# Altium schematic sheet remote_lab_test_beam_v2.00.SchDoc
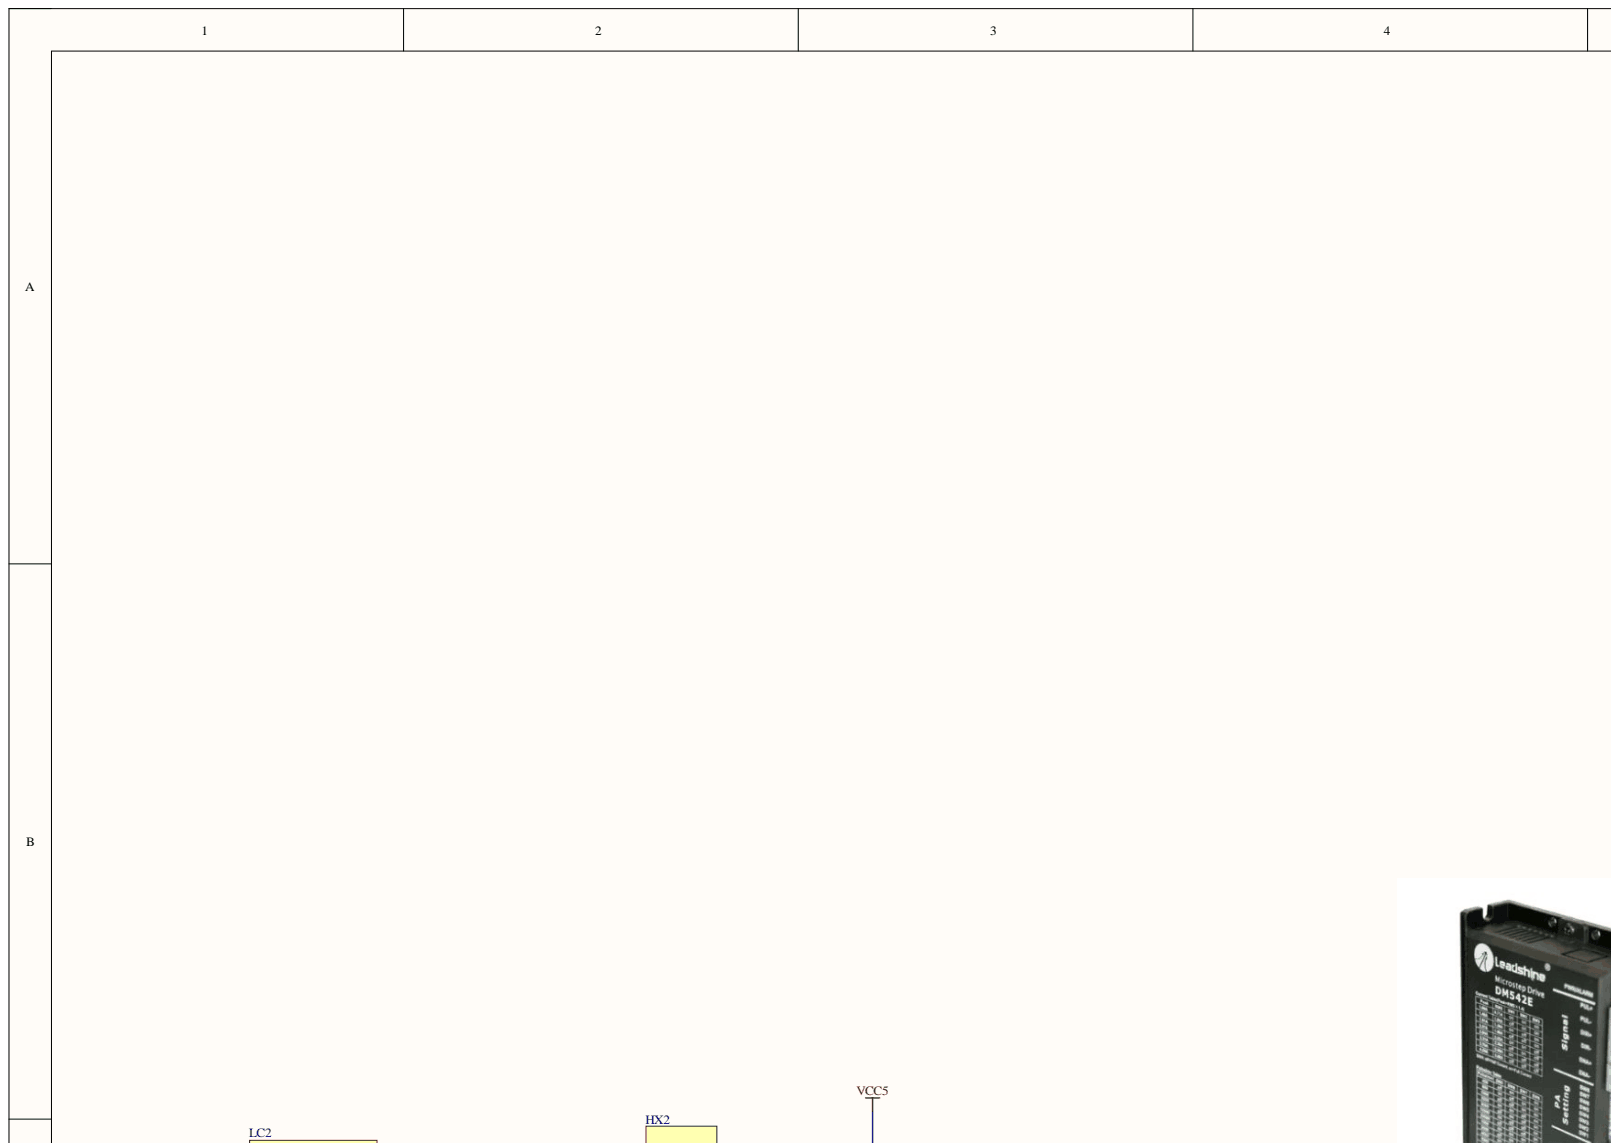
[diagram p0: remote_lab_test_beam_v2.00.SchDoc, top left]
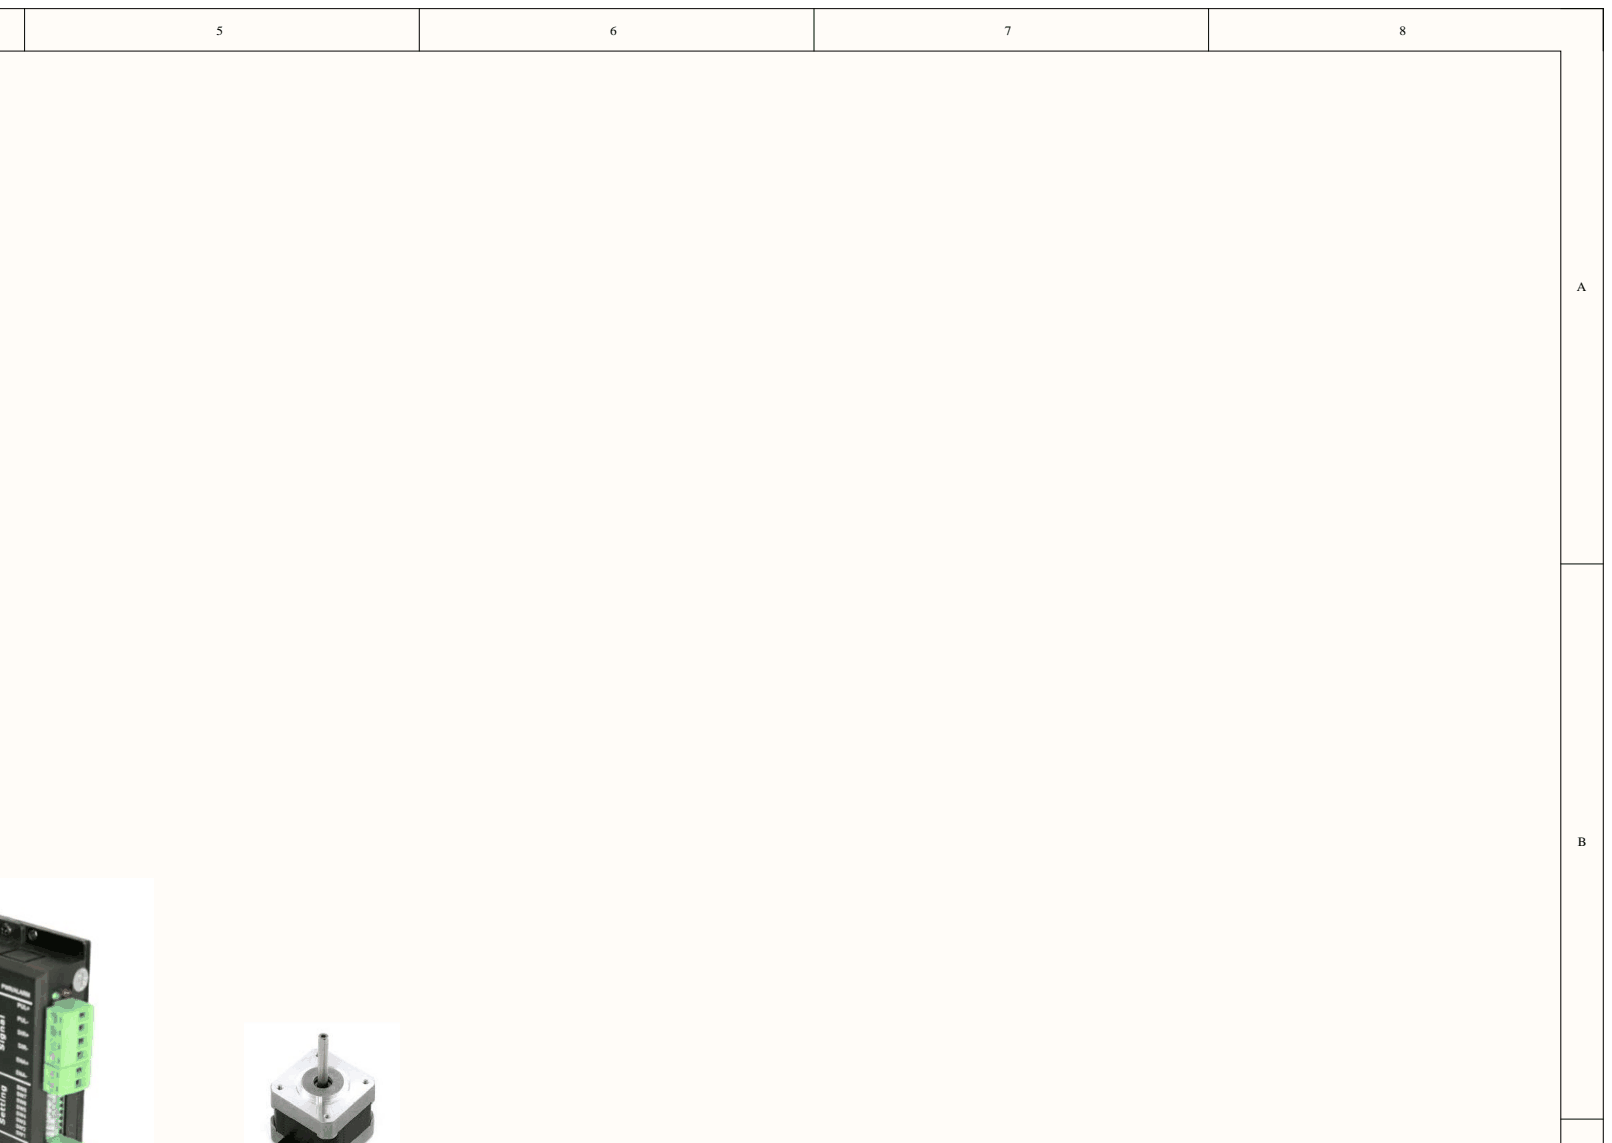
[diagram p1: remote_lab_test_beam_v2.00.SchDoc, top right]
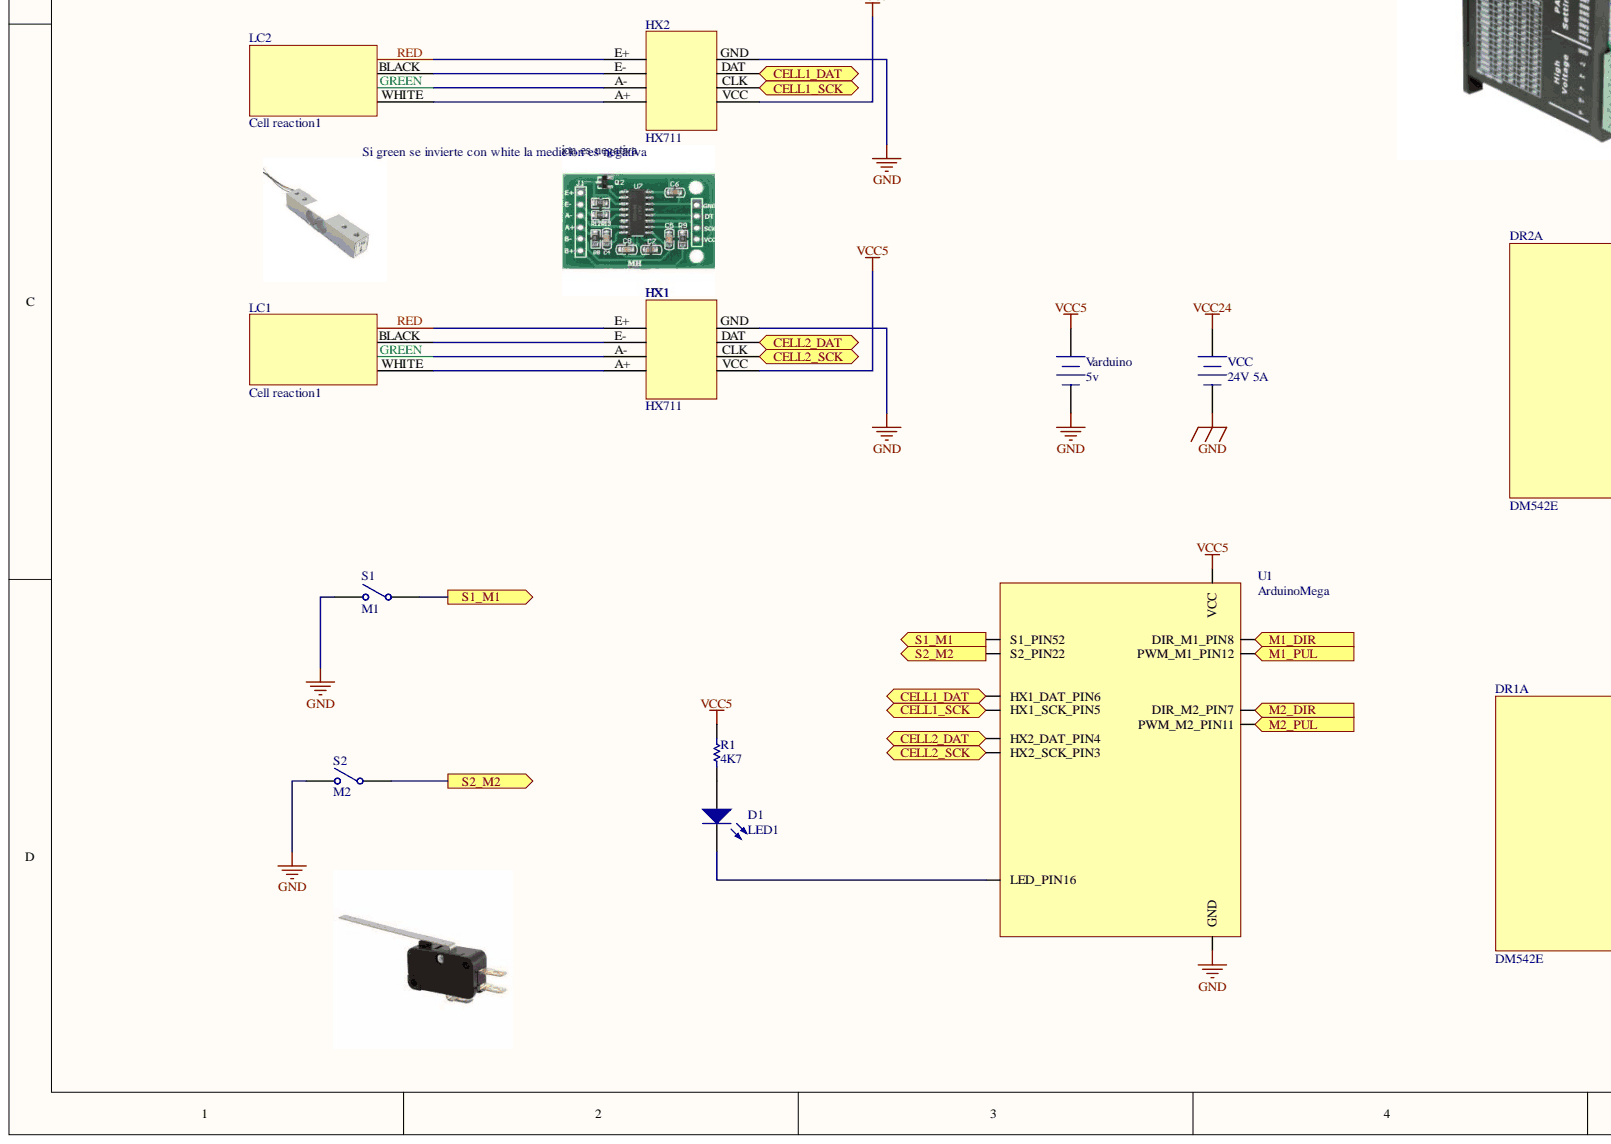
[diagram p2: remote_lab_test_beam_v2.00.SchDoc, bottom left]
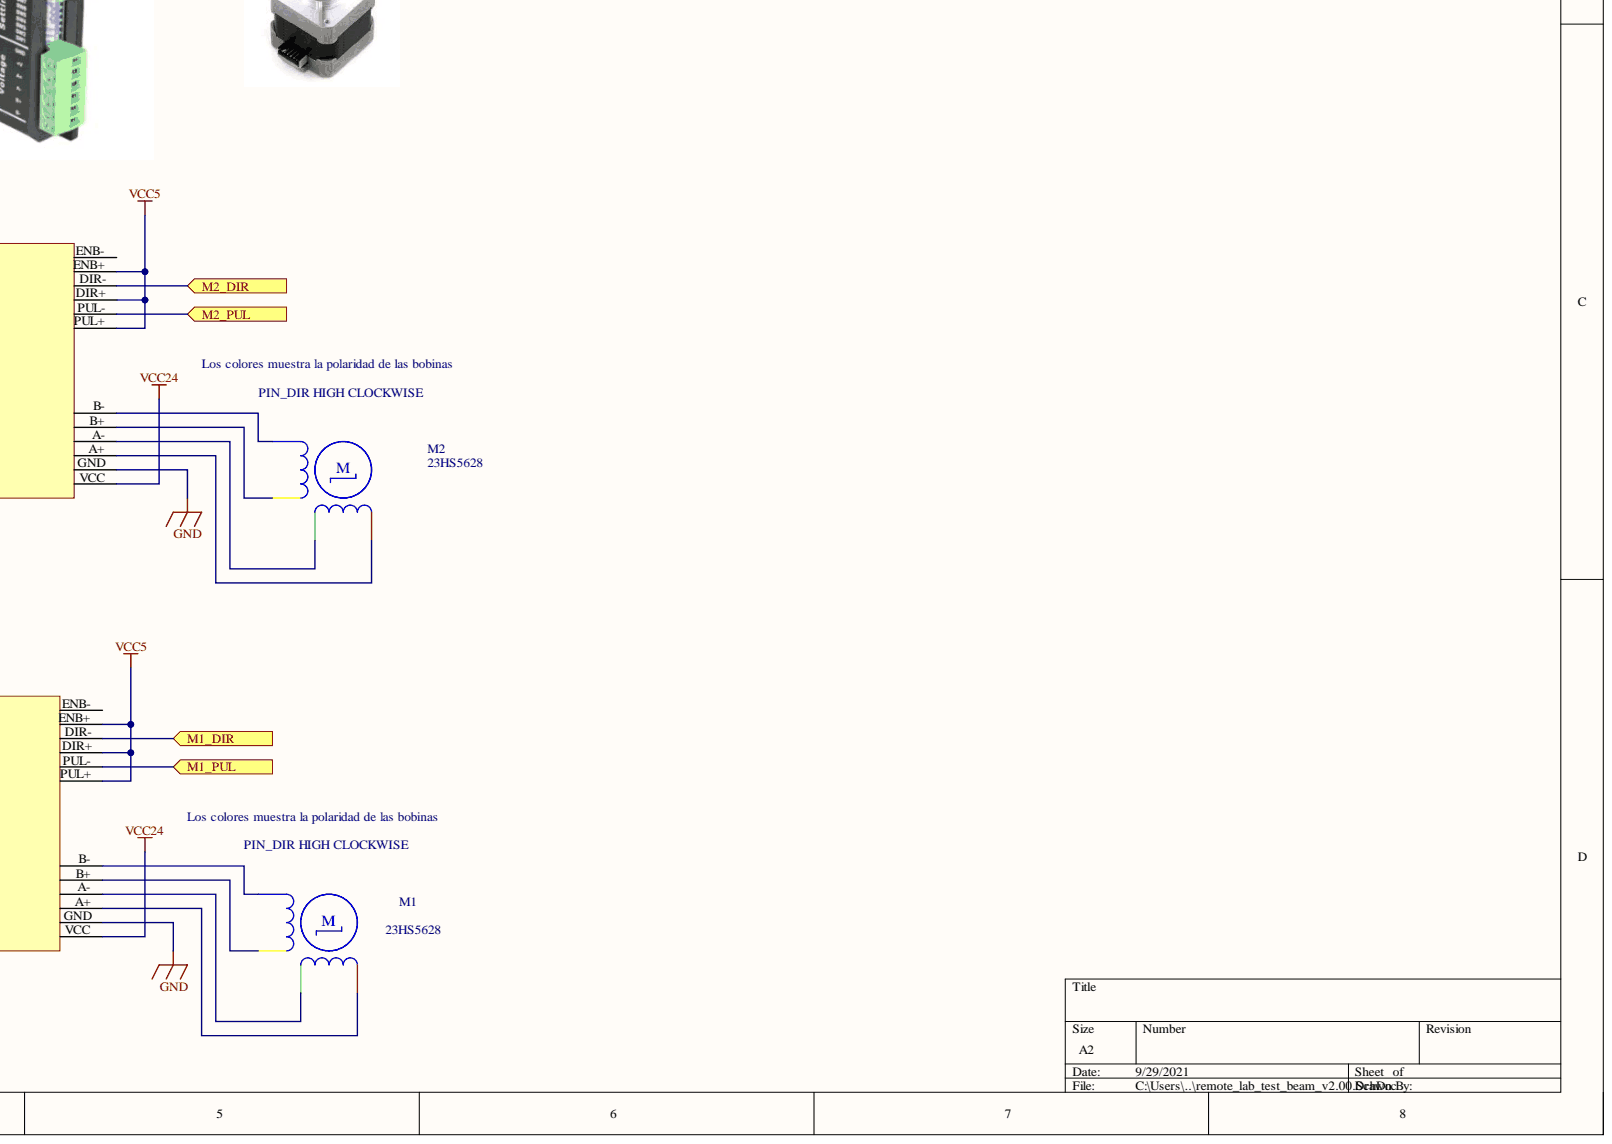
[diagram p3: remote_lab_test_beam_v2.00.SchDoc, bottom right]

## Parts
D1  LED1  [3.2X1.6X1.1]
DR1  DM542E  (part A)
DR2  DM542E  (part A)
HX1  HX711
HX2  HX711
LC1  Cell reaction1
LC2  Cell reaction1
M1  23HS5628  [DIP-6]
M2  23HS5628  [DIP-6]
R1  value 4K7  [AXIAL-0.3]
S1  M1  [SPST-2]
S2  M2  [SPST-2]
U1  ArduinoMega
Varduino  5v  [BAT-2]
VCC  24V 5A  [BAT-2]

## Ports
CELL1_DAT, CELL1_SCK, CELL2_DAT, CELL2_SCK, M1_DIR, M1_PUL, M2_DIR, M2_PUL, S1_M1, S2_M2

## Nets
GND: S1.2 S2.2 U1.VCC Varduino.2 VCC.2
VCC5: R1.2 U1.VCC Varduino.1
VCC24: VCC.1
CELL1_DAT: U1.HX1_DAT(HX1_DAT_PIN6) ; port CELL1_DAT
CELL1_SCK: U1.HX1_SCK(HX1_SCK_PIN5) ; port CELL1_SCK
CELL2_DAT: U1.HX2_DAT(HX2_DAT_PIN4) ; port CELL2_DAT
CELL2_SCK: U1.HX2_SCK(HX2_SCK_PIN3) ; port CELL2_SCK
M1_DIR: U1.DIR_M1(DIR_M1_PIN8) ; port M1_DIR
M1_PUL: U1.PWM_M1(PWM_M1_PIN12) ; port M1_PUL
M2_DIR: U1.VCC ; port M2_DIR, port M2_PUL
S1_M1: S1.1 U1.VCC ; port S1_M1
S2_M2: S2.1 U1.VCC ; port S2_M2
NetD1_1: D1.1 R1.1
NetD1_2: D1.2 U1.VCC

Not connected: DR1 A+ A- B+ B- DIR+ DIR- ENB+ ENB- GND PUL+ PUL- VCC; DR2 A+ A- B+ B- DIR+ DIR- ENB+ ENB- GND PUL+ PUL- VCC; HX1 A+ A- CLK DAT E+ E- GND VCC; HX2 A+ A- CLK DAT E+ E- GND VCC; LC1 BLACK GREEN RED WHITE; LC2 BLACK GREEN RED WHITE; M1 A1 A2 B1 B2; M2 A1 A2 B1 B2

## Library data of the parts
D1 = LED2: Typical RED, GREEN, YELLOW, AMBER GaAs LED; Component Kind: Standard; LatestRevisionDate: 17-Jul-2002; LatestRevisionNote: Re-released for DXP Platform.; PackageDescription: SM LED; 2 Flat Leads; PackageReference: 3.2X1.6X1.1; PackageVersion: Feb-2002; Published: 8-Jun-2000; Simulation: LED2; Library Name: remote_lab_test_beam_v2.00.SCHLIB; Publisher: Altium Limited
LC1 LC2 = load cell: Component Kind: Standard; Library Name: prj_mecanica.SchLib
M1 M2 = Motor Step_Nema23_2.8A: Motor Stepper; Code_JEDEC: MO-001-AM; Component Kind: Standard; LatestRevisionDate: 17-Jul-2002; LatestRevisionNote: Re-released for DXP Platform.; PackageReference: DIP-6; Published: 24-Mar-1999; Library Name: remote_lab_test_beam_v1.00.SCHLIB; Publisher: Altium Limited
R1 = Res1: Resistor; Component Kind: Standard; LatestRevisionDate: 17-Jul-2002; LatestRevisionNote: Re-released for DXP Platform.; PackageDescription: Axial Device, Thru-Hole; 2 Leads; 0.3 in Pin Spacing; PackageReference: AXIAL-0.3; Published: 8-Jun-2000; Signal Integrity: Res; Simulation: RESISTOR; Library Name: remote_lab_test_beam_v2.00.SCHLIB; Publisher: Altium Limited
S1 S2 = SW-SPST: Single-Pole, Single-Throw Switch; Component Kind: Standard; LatestRevisionDate: 17-Jul-2002; LatestRevisionNote: Re-released for DXP Platform.; Library Name: Miscellaneous Devices.IntLib; PackageDescription: Switch; 2 Leads; PackageReference: SPST-2; Published: 24-Mar-1999; Publisher: Altium Limited
Varduino VCC = Battery: Multicell Battery; Component Kind: Standard; LatestRevisionDate: 17-Jul-2002; LatestRevisionNote: Re-released for DXP Platform.; Library Name: Miscellaneous Devices.IntLib; PackageDescription: Battery; 2 Leads; PackageReference: BAT-2; Published: 24-Mar-1999; Publisher: Altium Limited
DR1 DR2 = DM542E: Component Kind: Standard; Library Name: remote_lab_test_beam_v1.00.SCHLIB
HX1 HX2 = HX711: Component Kind: Standard; Library Name: remote_lab_test_beam_v2.00.SCHLIB
U1 = ArduinoMega: Component Kind: Standard; Library Name: remote_lab_test_beam_v2.00.SCHLIB
